# Altium schematic sheet SPK_N.SchDoc
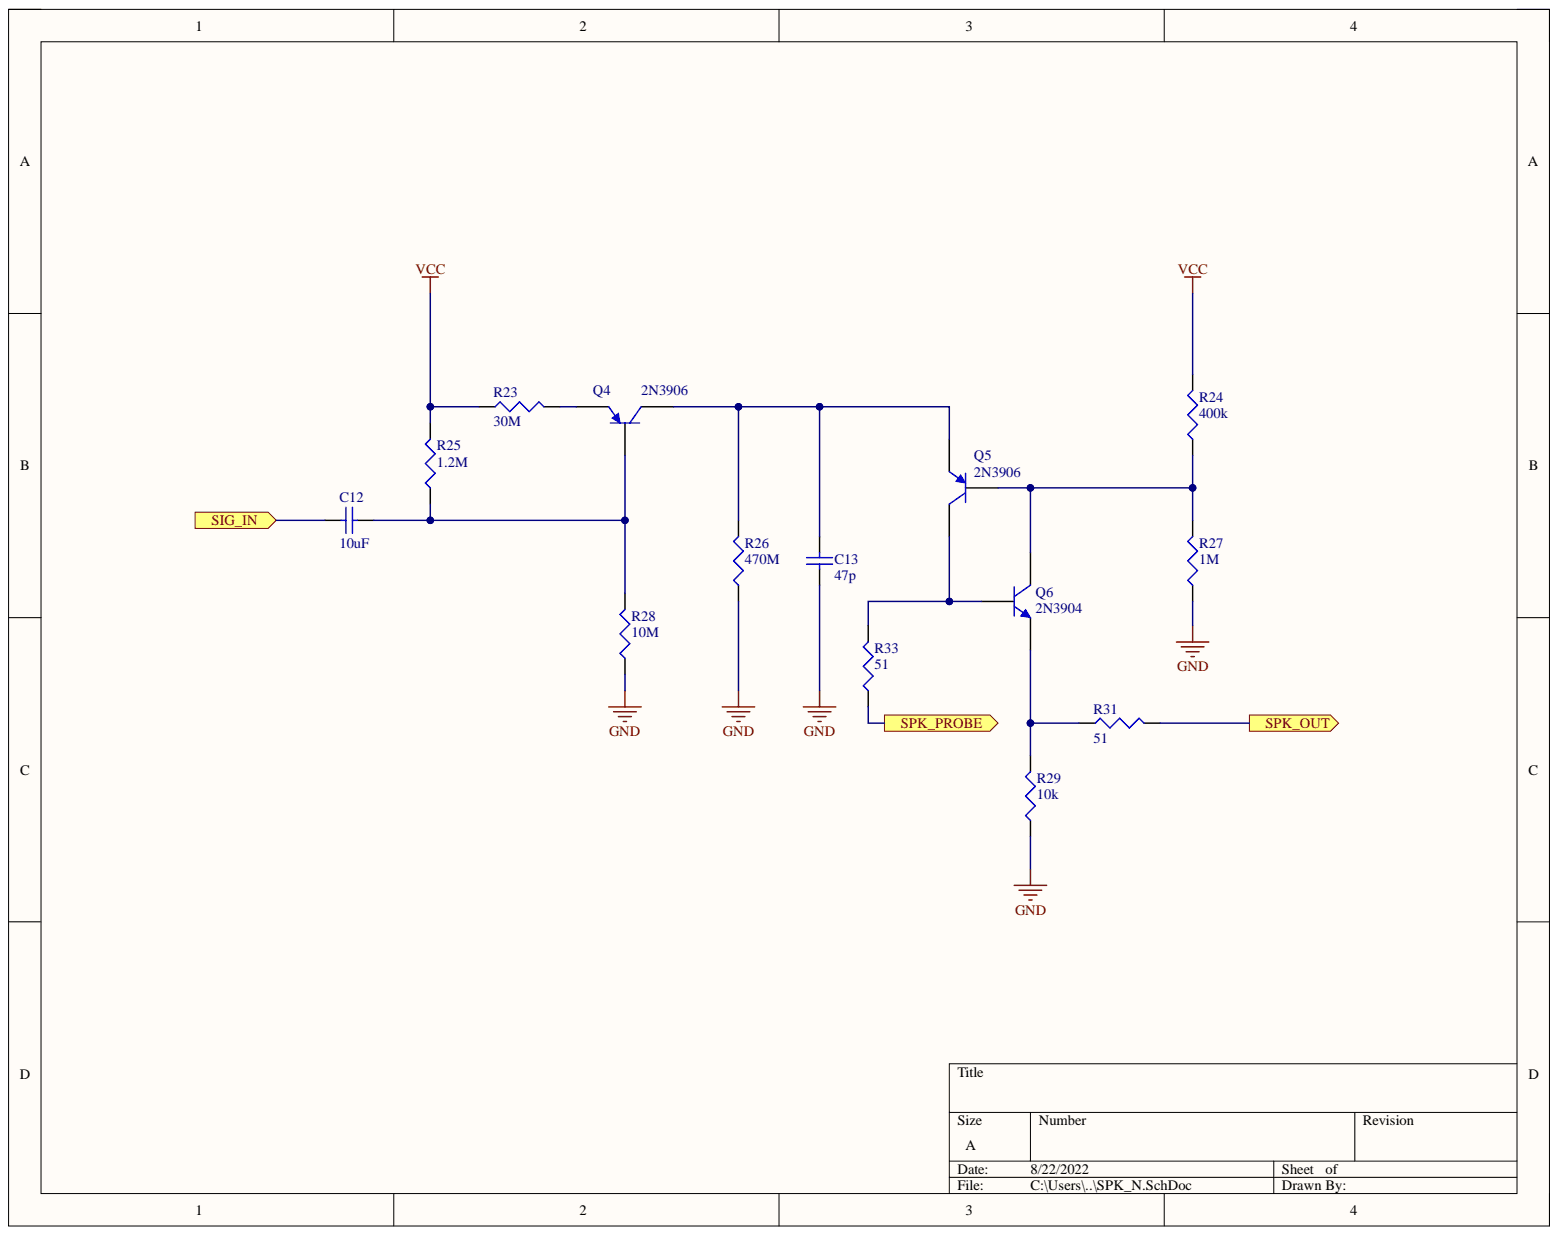
[diagram p0: SPK_N.SchDoc]

## Parts
C12  10uF  [SMD-0603]
C13  47p  [SMD-0603]
Q4  2N3906  [TO-92A]
Q5  2N3906  [TO-92A]
Q6  2N3904  [TO-92A]
R23  30M  [SMD-0603]
R24  400k  [SMD-0603]
R25  1.2M  [SMD-0603]
R26  470M  [SMD-0603]
R27  1M  [SMD-0603]
R28  10M  [SMD-0603]
R29  10k  [SMD-0603]
R31  51  [SMD-0603]
R33  51  [SMD-0603]

## Ports
SIG_IN, SPK_OUT, SPK_PROBE

## Nets
GND: C13.1 R26.1 R27.1 R28.1 R29.1
VCC: R23.1 R24.2 R25.2
SIG_IN: C12.1 ; port SIG_IN
SPK_OUT: R31.2 ; port SPK_OUT
SPK_PROBE: R33.1 ; port SPK_PROBE
NetC12_2: C12.2 Q4.2 R25.1 R28.2
NetC13_2: C13.2 Q4.3 Q5.1 R26.2
NetQ4_1: Q4.1 R23.2
NetQ5_2: Q5.2 Q6.3 R24.1 R27.2
NetQ5_3: Q5.3 Q6.2 R33.2
NetQ6_1: Q6.1 R29.2 R31.1

## Library data of the parts
C12 C13 = CAP0603: Ceramic Capacitor 0603; Component Kind: Standard; Library Name: LCR.SchLib
Q4 Q5 = 2N3906: PNP General Purpose Amplifier; Component Kind: Standard; ComponentLink1Description: Manufacturer Link; ComponentLink1URL: http://www.fairchildsemi.com/; ComponentLink2Description: Datasheet; ComponentLink2URL: http://www.fairchildsemi.com/ds/2N%2F2N3906.pdf; DatasheetVersion: 1997; LatestRevisionDate: 15-Jan-2003; LatestRevisionNote: PCB Footprint 'BCY-W3/D4.7' replaced; Library Name: Miscellaneous Devices.IntLib; PackageDescription: TO, Flat Index; 3 In-Line, Axial Leads; Body Dia. 4.5mm; Leads 0.51 x 0.48 mm (max); PackageReference: TO-92A; PackageVersion: Sep-1998; Published: 8-Jun-2000; Signal Integrity: 2N3906; Simulation: 2N3906; Publisher: Altium Limited
Q6 = 2N3904: NPN General Purpose Amplifier; Component Kind: Standard; ComponentLink1Description: Manufacturer Link; ComponentLink1URL: http://www.fairchildsemi.com/; ComponentLink2Description: Datasheet; ComponentLink2URL: http://www.fairchildsemi.com/ds/2N%2F2N3904.pdf; DatasheetVersion: 1997; LatestRevisionDate: 15-Jan-2003; LatestRevisionNote: PCB Footprint 'BCY-W3/D4.7' replaced; Library Name: Miscellaneous Devices.IntLib; PackageDescription: TO, Flat Index; 3 In-Line, Axial Leads; Body Dia. 4.5mm; Leads 0.51 x 0.48 mm (max); PackageReference: TO-92A; PackageVersion: Sep-1998; Published: 8-Jun-2000; Signal Integrity: 2N3904; Simulation: 2N3904; Publisher: Altium Limited
R23-R29 R31 R33 = RES0603: Resistor-0603; Component Kind: Standard; Library Name: LCR.SchLib
